# Altium schematic sheet rpi_adapter_mipi_in_out.SchDoc
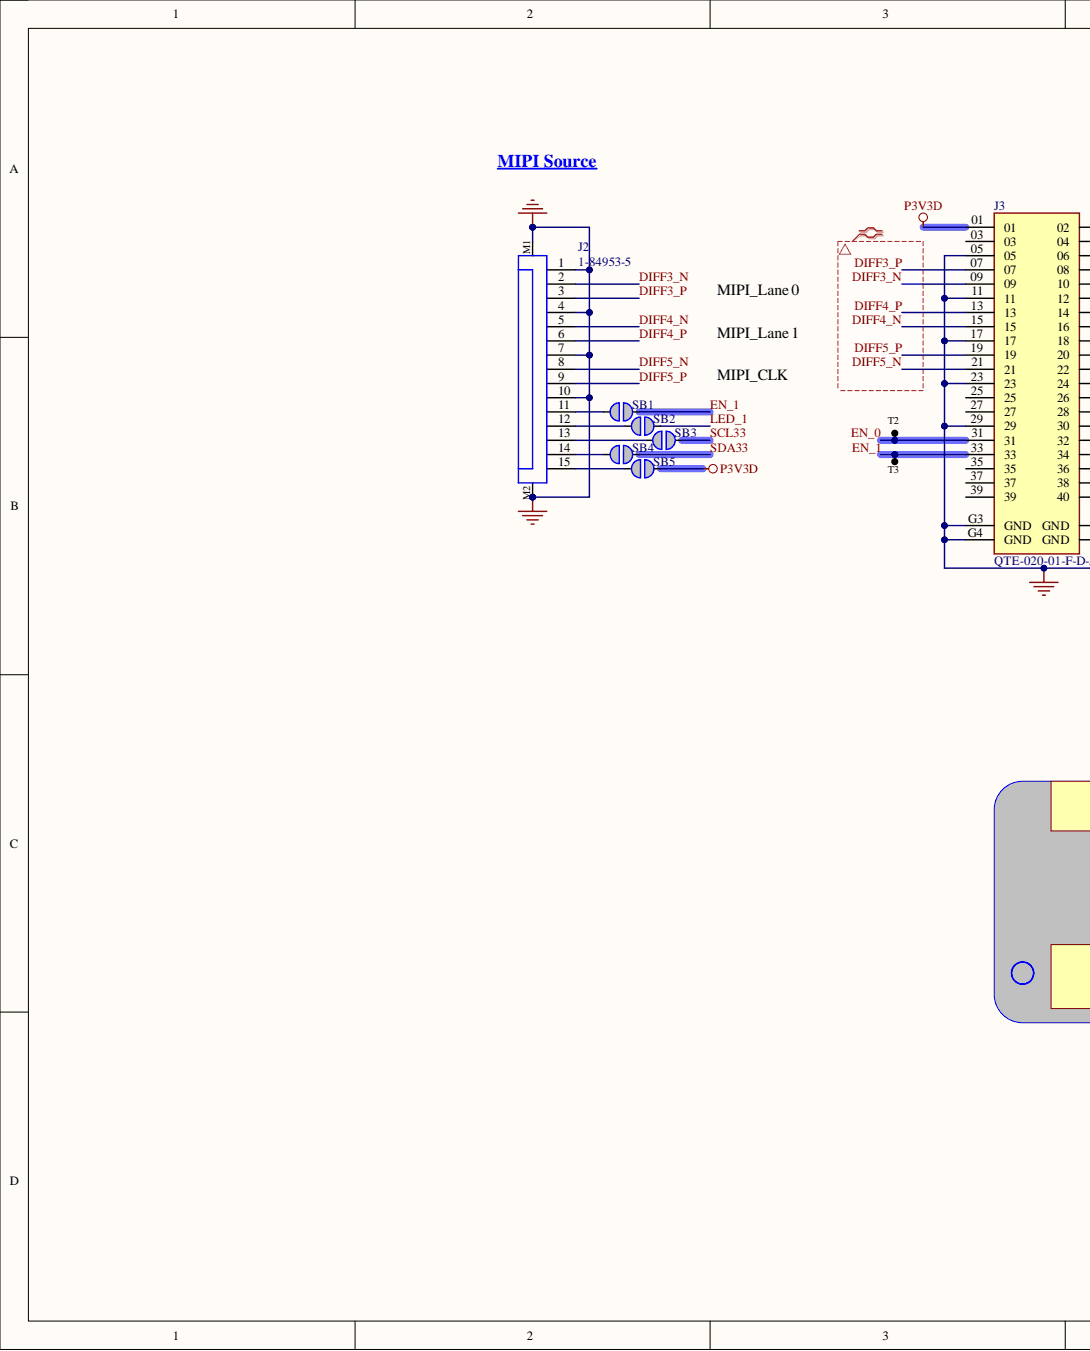
[diagram p0: rpi_adapter_mipi_in_out.SchDoc, left]
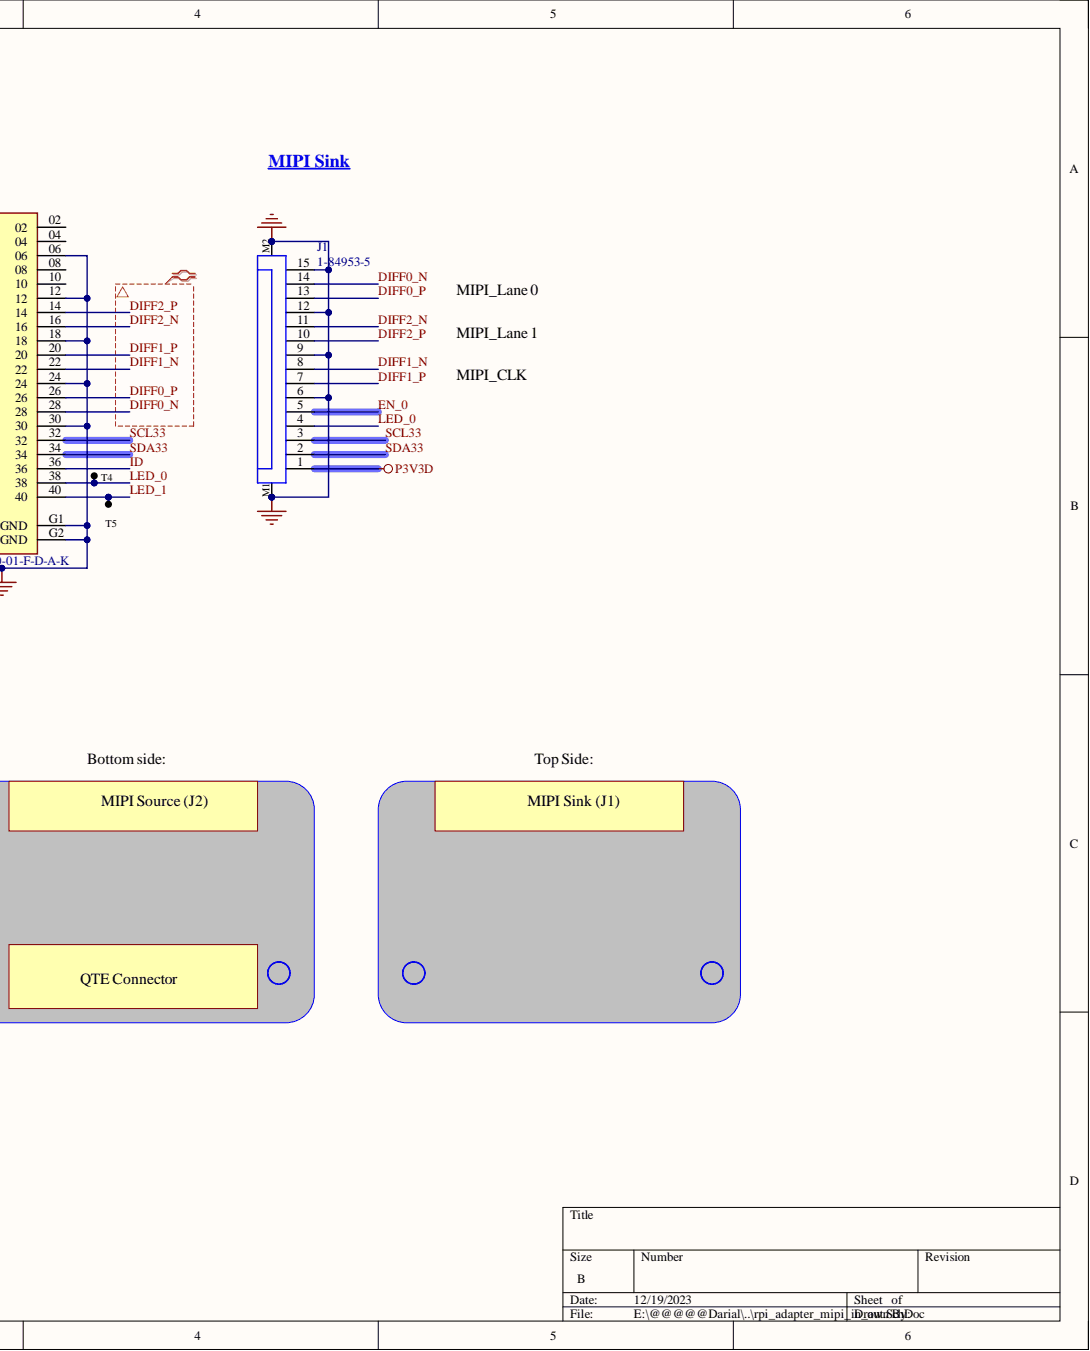
[diagram p1: rpi_adapter_mipi_in_out.SchDoc, right]

## Parts
J1  1-84953-5  [TE_1-84953-5]
J2  1-84953-5  [TE_1-84953-5]
J3  QTE-020-01-F-D-A-K  [SAMTEC_QTE-020-01-X-D-A]
SB1  Solderbridge  [Solderbridge]  (value not drawn)  (no BOM)
SB2  Solderbridge  [Solderbridge]  (value not drawn)  (no BOM)
SB3  Solderbridge  [Solderbridge]  (value not drawn)  (no BOM)
SB4  Solderbridge  [Solderbridge]  (value not drawn)  (no BOM)
SB5  Solderbridge  [Solderbridge]  (value not drawn)  (no BOM)
T2  TP_S  [TP 1mm]  (value not drawn)  (no BOM)
T3  TP_S  [TP 1mm]  (value not drawn)  (no BOM)
T4  TP_S  [TP 1mm]  (value not drawn)  (no BOM)
T5  TP_S  [TP 1mm]  (value not drawn)  (no BOM)

## Nets
GND: J1.6 J1.9 J1.12 J1.15 J1.M1 J1.M2 J2.1 J2.4 J2.7 J2.10 J2.M1 J2.M2 J3.05 J3.06 J3.11 J3.12 J3.17 J3.18 J3.23 J3.24 J3.29 J3.30 J3.G1(GND) J3.G2(GND) J3.G3(GND) J3.G4(GND)
P3V3D: J1.1 J3.01 SB5.1
DIFF0_N: J1.14 J3.28
DIFF0_P: J1.13 J3.26
DIFF1_N: J1.8 J3.22
DIFF1_P: J1.7 J3.20
DIFF2_N: J1.11 J3.16
DIFF2_P: J1.10 J3.14
DIFF3_N: J2.2 J3.09
DIFF3_P: J2.3 J3.07
DIFF4_N: J2.5 J3.15
DIFF4_P: J2.6 J3.13
DIFF5_N: J2.8 J3.21
DIFF5_P: J2.9 J3.19
EN_0: J1.5 J3.31 T2.1
EN_1: J3.33 SB1.1 T3.1
ID: J3.36
LED_0: J1.4 J3.38 T4.1
LED_1: J3.40 SB2.1 T5.1
SCL33: J1.3 J3.32 SB3.1
SDA33: J1.2 J3.34 SB4.1
NetJ2_11: J2.11 SB1.2
NetJ2_12: J2.12 SB2.2
NetJ2_13: J2.13 SB3.2
NetJ2_14: J2.14 SB4.2
NetJ2_15: J2.15 SB5.2

Not connected: J3 02 03 04 08 10 25 27 35 37 39

## Library data of the parts
J1 J2 = FPC - 15P - 2MP: Conn FPC Connector SKT 15 POS 1mm Solder RA SMD T/R; Manufacturer: TE Connectivity; Actuator Color: Black; Actuator Material: Nylon,Glass,Polyamide; Connector System: Flex-to-Board; Connector Type: Receptacle,Connector,Socket; Contact Current Rating: 1A; Contact Location: Top; Gender: Receptacle; Mating Alignment: Yes (With); Max Voltage Rating (AC): 200V; Number of Contacts: 15; PCB Mount Alignment: No (Without); PCB Mount Retention: With; PCB Mounting Orientation: Right Angle; Pitch: 0.039inch; Plating Thickness: 2um; Shielding: Unshielded; Wire/Cable Type: Flat Flex; Category: FFC / FPC; Component Kind: Standard; ComponentLink1Description: https://datasheet.ciiva.com/669/eng-cd-84953-a3-669110.pdf?src-supplier=Digi-Key; ComponentLink1URL: https://datasheet.ciiva.com/669/eng-cd-84953-a3-669110.pdf?src-supplier=Digi-Key; ComponentLink2Description: https://datasheet.ciiva.com/669/eng-cd-84953-a3-669111.pdf?src-supplier=Digi-Key; ComponentLink2URL: https://datasheet.ciiva.com/669/eng-cd-84953-a3-669111.pdf?src-supplier=Digi-Key; ComponentLink3Description: https://datasheet.ciiva.com/669/eng-cd-84953-a3-669112.pdf?src-supplier=Digi-Key; ComponentLink3URL: https://datasheet.ciiva.com/669/eng-cd-84953-a3-669112.pdf?src-supplier=Digi-Key; Contact Material: Bronze; Contact Plating: Tin,Bright; Current Rating: 1A; Depth: 7.3mm; ELV: Compliant; Flammability Rating: UL94 V-0; Height: 2.56mm; Housing Color: Natural; Housing Material: Polymer; Length: 22.92mm; Library Name: rpi_adapter.SCHLIB; Manufacturer Part Number: 1-84953-5; Max Operating Temperature: 85°C; Min Operating Temperature: -40°C; Mount: Surface Mount; Number of Positions: 15; Number of Rows: 1; Orientation: Right Angle; Package Quantity: 1200; Packaging: Tape and Reel; Pricing 1: 1=1.02000, 10=0.97700, 25=0.93400, 50=0.92100, 100=0.80470, 250=0.77460, 500=0.73158 (USD); Radiation Hardening: No; RoHS: Yes; RoHS Compliant: Yes; Sealable: No (Without); Series: FPC; Shrouded: Yes (With); Stock 1: 9836; Supplier 1: Digi-Key; Supplier Part Number 1: A101405CT-ND; Termination: SMD/SMT; Voltage Rating: 200V; Width: 0.2575inch
J3 = QSE-020-01-F-D-A-K: Q Strip® High-Speed Ground Plane Header, 0.80mm Pitch; Manufacturer: Samtec; Gender: Male; Mated Stacking Heights: 5mm; Number of Contacts: 40; Pitch: 0.031inch; Category: Board to Board Connectors; Component Kind: Standard; ComponentLink1Description: https://datasheet.ciiva.com/6924/qte-xxx-xx-x-d-xxx-mkt-6924765.pdf?src-supplier=Digi-Key; ComponentLink1URL: https://datasheet.ciiva.com/6924/qte-xxx-xx-x-d-xxx-mkt-6924765.pdf?src-supplier=Digi-Key; Contact Plating: Gold; Library Name: NX Module baseboard.SchLib; Manufacturer Part Number: QTE-020-01-F-D-A; Mounting Technology: Surface Mount; Number of Positions: 40; Number of Rows: 2; Package Quantity: 1; Packaging: Tray; Pricing: 1=5.61000, 10=5.09400, 25=4.82480, 40=4.71275, 80=4.48825, 230=3.92726, 440=3.81505, 945=3.25402, 2400=3.02960 (USD); RoHS: Yes; RoHS Compliant: Yes; Series: QStrip® QTE; Stock: 179; Supplier 1: Digi-Key; Supplier Part Number 1: SAM8846-ND; Termination: Solder
SB1-SB5 = Solderbridge: Artikkelnr Noca: N.A.; Artikkelnr Simpro: N.A.; Description: Solderbridge; Footprint Doc: Solderbridge; LatestRevisionDate: 2009-12-14; Library Name: AiC.SCHLIB; Part Number: Solderbridge; Pin Count: 2; Published: 2009-12-14; Value: Solderbridge; Publisher: Nordic Semiconductor
T2-T5 = TP_S: test point; Library Name: AiC.SCHLIB
